# Altium schematic sheet RPi_MB.SchDoc
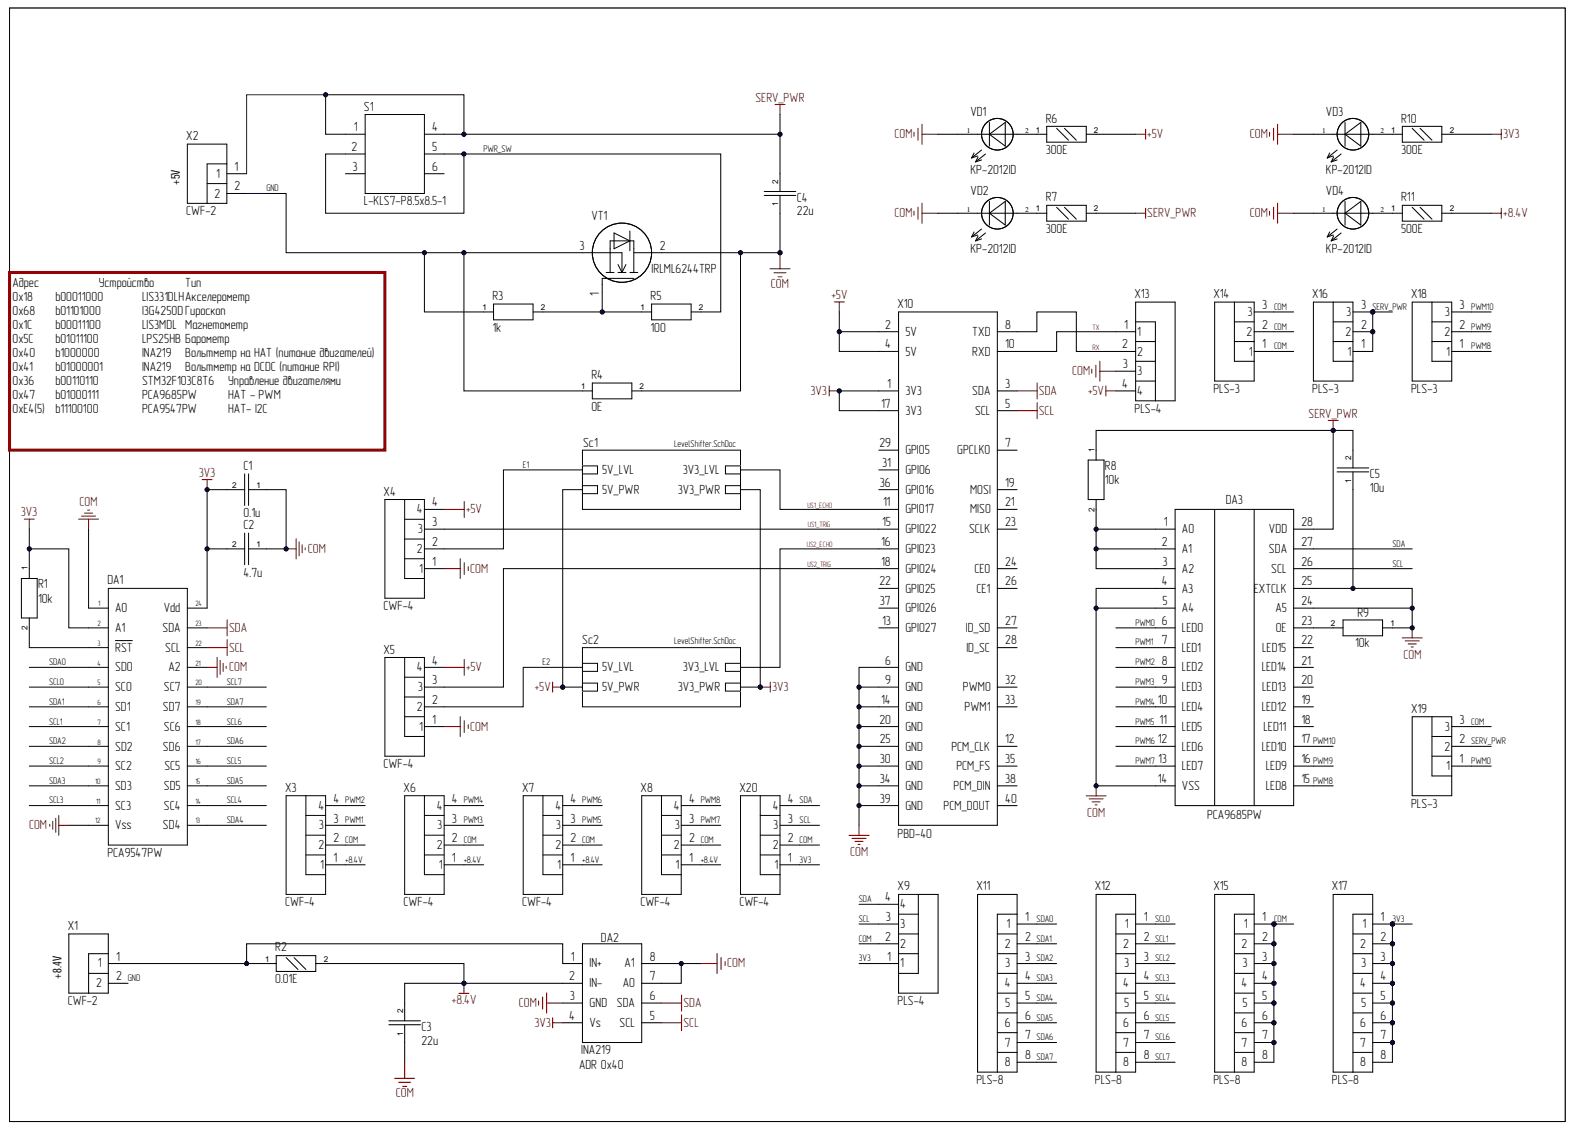
[diagram p0: RPi_MB.SchDoc]

## Parts
C1  value 0.1u  [0603]  (hidden comment: Кер. чип. конд. 0603 X7R 100нФ 16В 10%, GRM188R71C104KA01D)
C2  value 4.7u  [0603]  (hidden comment: Кер. чип. конд. 0603 X5R 4.7мкФ 6.3В 10% , GRM188R60J475KE19D)
C3  value 22u  [0805]  (hidden comment: Кер. чип. конд. 0603 X5R 10мкФ 25В 20%, GRM188R61E106M)
C4  value 22u  [0805]  (hidden comment: Кер. чип. конд. 0603 X5R 10мкФ 25В 20%, GRM188R61E106M)
C5  value 10u  [0805]  (hidden comment: Кер.ЧИП конд. 10 мкФ X5R 10% 16В 0805)
DA1  PCA9547PW  [TSSOP-24]
DA2  Type: INA219  [SOT-23-8]  (hidden comment: INA219AIDCNT, Двунаправленный монитор тока/питания с I2C интерфейсом, Zero-Drift [SOT-23-8])
DA3  Type: PCA9685PW  [TSSOP-28]  (hidden comment: PCA9685PW,112, Светодиодный контроллер 12-Бит PWM Fm+ I2C-bus, 16-канальный [TSSOP-28])
R1  value 10k  [0603]  (hidden comment: 0.1Вт 0603 10 кОм, 1%, Чип резистор (SMD))
R2  value 0.01E  [0805]
R3  value 1k  [0603]  (hidden comment: 0.1Вт 0603 1 кОм, 5%, Чип резистор (SMD))
R4  value 0E  [0603]  (hidden comment: 0.1Вт 0603 0 Ом, 5%, Чип резистор (SMD))
R5  value 100  [0603]  (hidden comment: 0.1Вт 0603 100 Ом, 5%, Чип резистор (SMD))
R6  value 300E  [0805]  (hidden comment: 0.125Вт 0805 300 Ом, 1%, Чип резистор (SMD))
R7  value 300E  [0805]  (hidden comment: 0.125Вт 0805 300 Ом, 1%, Чип резистор (SMD))
R8  value 10k  [0603]  (hidden comment: 0.1Вт 0603 10 кОм, 1%, Чип резистор (SMD))
R9  value 10k  [0603]  (hidden comment: 0.1Вт 0603 10 кОм, 1%, Чип резистор (SMD))
R10  value 300E  [0805]  (hidden comment: 0.125Вт 0805 300 Ом, 1%, Чип резистор (SMD))
R11  value 500E  [0805]  (hidden comment: 0.125Вт 0805 300 Ом, 1%, Чип резистор (SMD))
S1  Type: L-KLS7-P8.5x8.5-1  [PB22E09]  (hidden comment: KLS7-P8.5x8.5-1 (PB22E09), Кнопка миниатюрная с фиксацией 8.5мм (30В 0.3А))
VD1  Model: KP-2012ID  [LED_2012]  (hidden comment: KP-2012EC, Светодиод красный 2х1.2х1.1 12мКд)
VD2  Model: KP-2012ID  [LED_2012]  (hidden comment: KP-2012EC, Светодиод красный 2х1.2х1.1 12мКд)
VD3  Model: KP-2012ID  [LED_2012]  (hidden comment: KP-2012EC, Светодиод красный 2х1.2х1.1 12мКд)
VD4  Model: KP-2012ID  [LED_2012]  (hidden comment: KP-2012EC, Светодиод красный 2х1.2х1.1 12мКд)
VT1  Type: IRLML6244TRP  [SOT-23-3]  (hidden comment: IRLML6244TRPBF, Транзистор, N-канал 20В 6.3А [Micro3 / SOT-23])
X1  Notes: +8.4V  Type: CWF-2  [B2B-XH-A]  (hidden comment: CWF-2 (DS1069-2 M), Вилка на плату 2.50мм прямая закрытая)
X2  Notes: +5V  Type: CWF-2  [B2B-XH-A]  (hidden comment: CWF-2 (DS1069-2 M), Вилка на плату 2.50мм прямая закрытая)
X3  Type: CWF-4  [B4B-XH-A]  (hidden comment: CWF-4 (DS1069-4 M), Вилка на плату 2.50мм прямая закрытая)
X4  Type: CWF-4  [B4B-XH-A]  (hidden comment: CWF-4 (DS1069-4 M), Вилка на плату 2.50мм прямая закрытая)
X5  Type: CWF-4  [B4B-XH-A]  (hidden comment: CWF-4 (DS1069-4 M), Вилка на плату 2.50мм прямая закрытая)
X6  Type: CWF-4  [B4B-XH-A]  (hidden comment: CWF-4 (DS1069-4 M), Вилка на плату 2.50мм прямая закрытая)
X7  Type: CWF-4  [B4B-XH-A]  (hidden comment: CWF-4 (DS1069-4 M), Вилка на плату 2.50мм прямая закрытая)
X8  Type: CWF-4  [B4B-XH-A]  (hidden comment: CWF-4 (DS1069-4 M), Вилка на плату 2.50мм прямая закрытая)
X9  Type: PLS-4  [SNP346-4VP21-1]  (hidden comment: PLS-4 (DS-1021-1x4), Вилка штыревая 2.54мм 1x4 прямая тип1)
X10  Type: PBD-40  [PBD-40-RPi3]  (hidden comment: PBD-40 (DS1023-2X20), Гнездо на плату 2.54мм 2х20 прямое)
X11  Type: PLS-8  [SNP346-8RP21-1]  (hidden comment: PLS-8, (KLS1-207-1-08-S))
X12  Type: PLS-8  [SNP346-8RP21-1]  (hidden comment: PLS-8, (KLS1-207-1-08-S))
X13  Type: PLS-4  [SNP346-4VP21-1]  (hidden comment: PBS-4 (DS-1023 - 1x4), Гнездо на плату 2.54мм 1х4 прямое)
X14  Type: PLS-3  [SNP346-3VP21-1]  (hidden comment: PLS-3 (DS1021-1x3), Вилка штыревая 2.54мм 1x3 прямая тип1)
X15  Type: PLS-8  [SNP346-8RP21-1]  (hidden comment: PLS-8, (KLS1-207-1-08-S))
X16  Type: PLS-3  [SNP346-3VP21-1]  (hidden comment: PLS-3 (DS1021-1x3), Вилка штыревая 2.54мм 1x3 прямая тип1)
X17  Type: PLS-8  [SNP346-8RP21-1]  (hidden comment: PLS-8, (KLS1-207-1-08-S))
X18  Type: PLS-3  [SNP346-3VP21-1]  (hidden comment: PLS-3 (DS1021-1x3), Вилка штыревая 2.54мм 1x3 прямая тип1)
X19  Type: PLS-3  [SNP346-3VP21-1]  (hidden comment: PLS-3 (DS1021-1x3), Вилка штыревая 2.54мм 1x3 прямая тип1)
X20  Type: CWF-4  [B4B-XH-A]  (hidden comment: CWF-4 (DS1069-4 M), Вилка на плату 2.50мм прямая закрытая)

## Nets
+5V: R6.2 X4.4 X5.4 X10.2(5V) X10.4(5V) X13.4
3V3: C1.2 C2.2 DA1.2(A1) DA1.24(Vdd) DA2.4(Vs) R1.1 R10.2 X9.1 X10.1(3V3) X10.17(3V3) X17.1 X17.2 X17.3 X17.4 X17.5 X17.6 X17.7 X17.8 X20.1
+8.4V: C3.2 DA2.2(IN-) R2.2 R11.2 X3.1 X6.1 X7.1 X8.1
COM: C1.1 C2.1 C3.1 C4.1 C5.1 DA1.1(A0) DA1.12(Vss) DA1.21(A2) DA2.3(GND) DA2.7(A0) DA2.8(A1) DA3.4(A3) DA3.5(A4) DA3.14(VSS) DA3.24(A5) DA3.25(EXTCLK) R4.2 R9.1 VD1.1 VD2.1 VD3.1 VD4.1 VT1.2 X3.2 X4.1 X5.1 X6.2 X7.2 X8.2 X9.2 X10.6(GND) X10.9(GND) X10.14(GND) X10.20(GND) X10.25(GND) X10.30(GND) X10.34(GND) X10.39(GND) X13.3 X14.1 X14.2 X14.3 X15.1 X15.2 X15.3 X15.4 X15.5 X15.6 X15.7 X15.8 X19.3 X20.2
E1: X4.2
E2: X5.2
GND: R3.1 R4.1 VT1.3 X1.2 X2.2
PWM0: DA3.6(LED0) X19.1
PWM1: DA3.7(LED1) X3.3
PWM2: DA3.8(LED2) X3.4
PWM3: DA3.9(LED3) X6.3
PWM4: DA3.10(LED4) X6.4
PWM5: DA3.11(LED5) X7.3
PWM6: DA3.12(LED6) X7.4
PWM7: DA3.13(LED7) X8.3
PWM8: DA3.15(LED8) X8.4 X18.1
PWM9: DA3.16(LED9) X18.2
PWM10: DA3.17(LED10) X18.3
PWR_SW: R5.2 S1.2 S1.5
RX: X10.8(TXD) X13.2
SCL: DA1.22(SCL) DA2.5(SCL) DA3.26(SCL) X9.3 X10.5(SCL) X20.3
SCL0: DA1.5(SC0) X12.1
SCL1: DA1.7(SC1) X12.2
SCL2: DA1.9(SC2) X12.3
SCL3: DA1.11(SC3) X12.4
SCL4: DA1.14(SC4) X12.5
SCL5: DA1.16(SC5) X12.6
SCL6: DA1.18(SC6) X12.7
SCL7: DA1.20(SC7) X12.8
SDA: DA1.23(SDA) DA2.6(SDA) DA3.27(SDA) X9.4 X10.3(SDA) X20.4
SDA0: DA1.4(SD0) X11.1
SDA1: DA1.6(SD1) X11.2
SDA2: DA1.8(SD2) X11.3
SDA3: DA1.10(SD3) X11.4
SDA4: DA1.13(SD4) X11.5
SDA5: DA1.15(SD5) X11.6
SDA6: DA1.17(SD6) X11.7
SDA7: DA1.19(SD7) X11.8
SERV_PWR: C4.2 C5.2 DA3.28(VDD) R7.2 R8.1 S1.1 S1.4 X2.1 X16.1 X16.2 X16.3 X19.2
TX: X10.10(RXD) X13.1
US1_ECHO: X10.11(GPIO17)
US1_TRIG: X4.3 X10.15(GPIO22)
US2_ECHO: X10.16(GPIO23)
US2_TRIG: X5.3 X10.18(GPIO24)
NetDA1_3: DA1.3(RST) R1.2
NetDA2_1: DA2.1(IN+) R2.1 X1.1
NetDA3_1: DA3.1(A0) DA3.2(A1) DA3.3(A2) R8.2
NetDA3_18: DA3.18(LED11)
NetDA3_19: DA3.19(LED12)
NetDA3_20: DA3.20(LED13)
NetDA3_21: DA3.21(LED14)
NetDA3_22: DA3.22(LED15)
NetDA3_23: DA3.23(OE) R9.2
NetR3_2: R3.2 R5.1 VT1.1
NetR6_1: R6.1 VD1.2
NetR7_1: R7.1 VD2.2
NetR10_1: R10.1 VD3.2
NetR11_1: R11.1 VD4.2
NetS1_3: S1.3
NetS1_6: S1.6
NetX10_7: X10.7(GPCLK0)
NetX10_12: X10.12(PCM_CLK)
NetX10_13: X10.13(GPIO27)
NetX10_19: X10.19(MOSI)
NetX10_21: X10.21(MISO)
NetX10_22: X10.22(GPIO25)
NetX10_23: X10.23(SCLK)
NetX10_24: X10.24(CE0)
NetX10_26: X10.26(CE1)
NetX10_27: X10.27(ID_SD)
NetX10_28: X10.28(ID_SC)
NetX10_29: X10.29(GPIO5)
NetX10_31: X10.31(GPIO6)
NetX10_32: X10.32(PWM0)
NetX10_33: X10.33(PWM1)
NetX10_35: X10.35(PCM_FS)
NetX10_36: X10.36(GPIO16)
NetX10_37: X10.37(GPIO26)
NetX10_38: X10.38(PCM_DIN)
NetX10_40: X10.40(PCM_DOUT)

## Library data of the parts
C1 C2 = Capacitor: Неполярный конденсатор; Component Kind: Standard; Library Name: Capacitor.IntLib; Tolerance: 10%
  C1: HelpURL: https://www.chipdip.ru/product/grm188r71c104ka01d; Voltage: 16 В; Zakaz: Кер. чип. конд. 0603 X7R 100нФ 16В 10%, GRM188R71C104KA01D
  C2: HelpURL: https://www.chipdip.ru/product/grm31cr61a476me15l; Voltage: 6.3 В; Zakaz: Кер. чип. конд. 0603 X5R 4.7мкФ 6.3В 10% , GRM188R60J475KE19D
C3 C4 = Capacitor: Неполярный конденсатор; Voltage: 10 В; Component Kind: Standard; HelpURL: https://www.chipdip.ru/product/grm21br60j226m; Library Name: Capacitor.IntLib; Tolerance: 20%; Zakaz: Кер. чип. конд. 0603 X5R 10мкФ 25В 20%, GRM188R61E106M
C5 = Capacitor: Неполярный конденсатор; Tolerance: 20%; Voltage: 10 В; Component Kind: Standard; HelpURL: https://www.chipdip.ru/product0/9000671061; Library Name: Capacitor.IntLib; Zakaz: Кер.ЧИП конд. 10 мкФ X5R 10% 16В 0805
DA1 = PCA9547: Component Kind: Standard; ComponentLink1Description: Datasheet; ComponentLink1URL: https://www.nxp.com/docs/en/data-sheet/PCA9547.pdf; HelpURL: https://www.chipdip.ru/product1/8002979250; Library Name: IC.IntLib; Type: PCA9547PW; Zakaz: PCA9547PW
DA2 = INA219xxxx: High-side bi-directional zero-drift current shunt and Power Monitor with an I²C interface; Voltage - Supply: +3..5.5V; Component Kind: Standard; ComponentLink1Description: Datasheet; ComponentLink1URL: https://static.chipdip.ru/lib/766/DOC004766634.pdf; HelpURL: https://www.chipdip.ru/product/ina219aidcnt-ti?from=suggest_product; Library Name: IC.IntLib; Operating Temperature: 0°C ~ 70°C; Zakaz: INA219AIDCNT, Двунаправленный монитор тока/питания с I2C интерфейсом, Zero-Drift [SOT-23-8]
DA3 = PC9685PW: IC I/O EXPANDER I2C 8B 16TSSOP; Manufacturer: NXP USA Inc.; Clock Frequency: 400kHz; Current - Output Source/Sink: 10mA, 25mA; Interface: I²C, SMBus; Interrupt Output: Yes; Number of I/O: 8; Output Type: Push-Pull; Voltage - Supply: 2.3V ~ 5.5V; Case: 16-TSSOP (0.173", 4.40mm Width); Component Kind: Standard; HelpURL: https://www.chipdip.ru/product/pca9685pw-112-nxp; Library Name: IC.IntLib; Mounting Type: Surface Mount; Operating Temperature: -40°C ~ 85°C; Zakaz: PCA9685PW,112, Светодиодный контроллер 12-Бит PWM Fm+ I2C-bus, 16-канальный [TSSOP-28]
R1 R3-R5 R8 R9 = Resistor: Component Kind: Standard; Library Name: Resistors.IntLib
  R1 R8 R9: HelpURL: https://www.chipdip.ru/product0/9000079736; Zakaz: 0.1Вт 0603 10 кОм, 1%, Чип резистор (SMD)
  R3: HelpURL: https://www.chipdip.ru/product0/10149; Zakaz: 0.1Вт 0603 1 кОм, 5%, Чип резистор (SMD)
  R4: HelpURL: https://www.chipdip.ru/product0/9000070541; Zakaz: 0.1Вт 0603 0 Ом, 5%, Чип резистор (SMD)
  R5: HelpURL: https://www.chipdip.ru/product0/41371; Zakaz: 0.1Вт 0603 100 Ом, 5%, Чип резистор (SMD)
R2 = Res 0.125: Power: 0.125 Вт; Component Kind: Standard; Library Name: Resistors.IntLib
R6 R7 R10 R11 = Res 0.125: Component Kind: Standard; HelpURL: https://www.chipdip.ru/product0/9000079473; Library Name: Resistors.IntLib; Power: 0.125 Вт; Zakaz: 0.125Вт 0805 300 Ом, 1%, Чип резистор (SMD)
S1 = PB22E09: Manufacturer: KLS electronic co ltd; Component Kind: Standard; ComponentLink1Description: New link; HelpURL: https://www.chipdip.ru/product/ps850l-pb22e09; Zakaz: KLS7-P8.5x8.5-1 (PB22E09), Кнопка миниатюрная с фиксацией 8.5мм (30В 0.3А); Library Name: Connection.IntLib
VD1-VD4 = LED: Color: RED; Component Kind: Standard; Description: LED; HelpUrl: https://www.chipdip.ru/product/kp-2012ec; Library Name: Diodes.IntLib; Zakaz: KP-2012EC, Светодиод красный 2х1.2х1.1 12мКд
VT1 = IRLML6244TRPBF: MOSFET N-CH 20V 6.3A SOT23-3; Current - Continuous Drain (Id) @ 25°C: 6.3A (Ta); Drive Voltage (Max Rds On, Min Rds On): 2.5V, 4.5V; FET Type: N-Channel; Gate Charge (Qg) (Max) @ Vgs: 8.9nC @ 4.5V; Input Capacitance (Ciss) (Max) @ Vds: 700pF @ 16V; Power Dissipation (Max): 1.3W (Ta); Rds On (Max) @ Id, Vgs: 21mOhm @ 6.3A, 4.5V; Vgs (Max): ±12V; Vgs(th) (Max) @ Id: 1.1V @ 10µA; Case: TO-236-3, SC-59, SOT-23-3; Component Kind: Standard; Drain to Source Voltage (Vdss): 20V; HelpURL: https://www.chipdip.ru/product/irlml6244trpbf; Operating Temperature: -55°C ~ 150°C (TJ); Technology: MOSFET (Metal Oxide); Zakaz: IRLML6244TRPBF, Транзистор, N-канал 20В 6.3А [Micro3 / SOT-23]; Library Name: Tranzistor.IntLib
X1 X2 = Connector_2: Component Kind: Standard; HelpURL: https://www.chipdip.ru/product/cwf-2; Zakaz: CWF-2 (DS1069-2 M), Вилка на плату 2.50мм прямая закрытая; Library Name: Connection.IntLib
X3 = Connector_4: Notes: REAR_LEFT; Component Kind: Standard; HelpURL: https://www.chipdip.ru/product/cwf-4; Zakaz: CWF-4 (DS1069-4 M), Вилка на плату 2.50мм прямая закрытая; Library Name: Connection.IntLib
X4 = Connector_4: Notes: US1; Component Kind: Standard; HelpURL: https://www.chipdip.ru/product/cwf-4; Zakaz: CWF-4 (DS1069-4 M), Вилка на плату 2.50мм прямая закрытая; Library Name: Connection.IntLib
X5 = Connector_4: Notes: US2; Component Kind: Standard; ComponentLink1Description: Datasheet; ComponentLink1URL: https://www.terraelectronica.ru/pdf/show?pdf_file=%252Fds%252Fpdf%252FC%252FCWF-4R.pdf; HelpURL: https://www.chipdip.ru/product/cwf-4; Zakaz: CWF-4 (DS1069-4 M), Вилка на плату 2.50мм прямая закрытая; Library Name: Connection.IntLib
X6 = Connector_4: Notes: FRONT_LEFT; Component Kind: Standard; HelpURL: https://www.chipdip.ru/product/cwf-4; Zakaz: CWF-4 (DS1069-4 M), Вилка на плату 2.50мм прямая закрытая; Library Name: Connection.IntLib
X7 = Connector_4: Notes: REAR_RIGHT; Component Kind: Standard; HelpURL: https://www.chipdip.ru/product/cwf-4; Zakaz: CWF-4 (DS1069-4 M), Вилка на плату 2.50мм прямая закрытая; Library Name: Connection.IntLib
X8 X20 = Connector_4: Notes: FRONT_RIGHT; Component Kind: Standard; HelpURL: https://www.chipdip.ru/product/cwf-4; Zakaz: CWF-4 (DS1069-4 M), Вилка на плату 2.50мм прямая закрытая; Library Name: Connection.IntLib
X9 = Connector_4: Notes: IMU; Component Kind: Standard; HelpURL: https://www.chipdip.ru/product/pls-4; Zakaz: PLS-4 (DS-1021-1x4), Вилка штыревая 2.54мм 1x4 прямая тип1; Library Name: Connection.IntLib
X10 = RPi3_GPIO_ext: Raspberry Pi 3B GPIO extension connector model; Component Kind: Standard; HelpURL: https://www.chipdip.ru/product/pbd-40; Zakaz: PBD-40 (DS1023-2X20), Гнездо на плату 2.54мм 2х20 прямое; Library Name: Connection.IntLib
X11 X12 X15 X17 = Connector_8: Component Kind: Standard; HelpURL: https://www.chipdip.ru/product0/8001782046; Zakaz: PLS-8, (KLS1-207-1-08-S); Library Name: Connection.IntLib
X13 = Connector_4: Component Kind: Standard; HelpURL: https://www.chipdip.ru/product/pbs-4; Zakaz: PBS-4 (DS-1023 - 1x4), Гнездо на плату 2.54мм 1х4 прямое; Library Name: Connection.IntLib
X14 X16 X18 X19 = Connector_3: Notes: S_RUL; Component Kind: Standard; HelpURL: https://www.chipdip.ru/product/pls-3; Zakaz: PLS-3 (DS1021-1x3), Вилка штыревая 2.54мм 1x3 прямая тип1; Library Name: Connection.IntLib
